# Altium schematic sheet Mako DSP QuadFlash and Boot.SchDoc("Mako DSP QuadFlash and Boot")
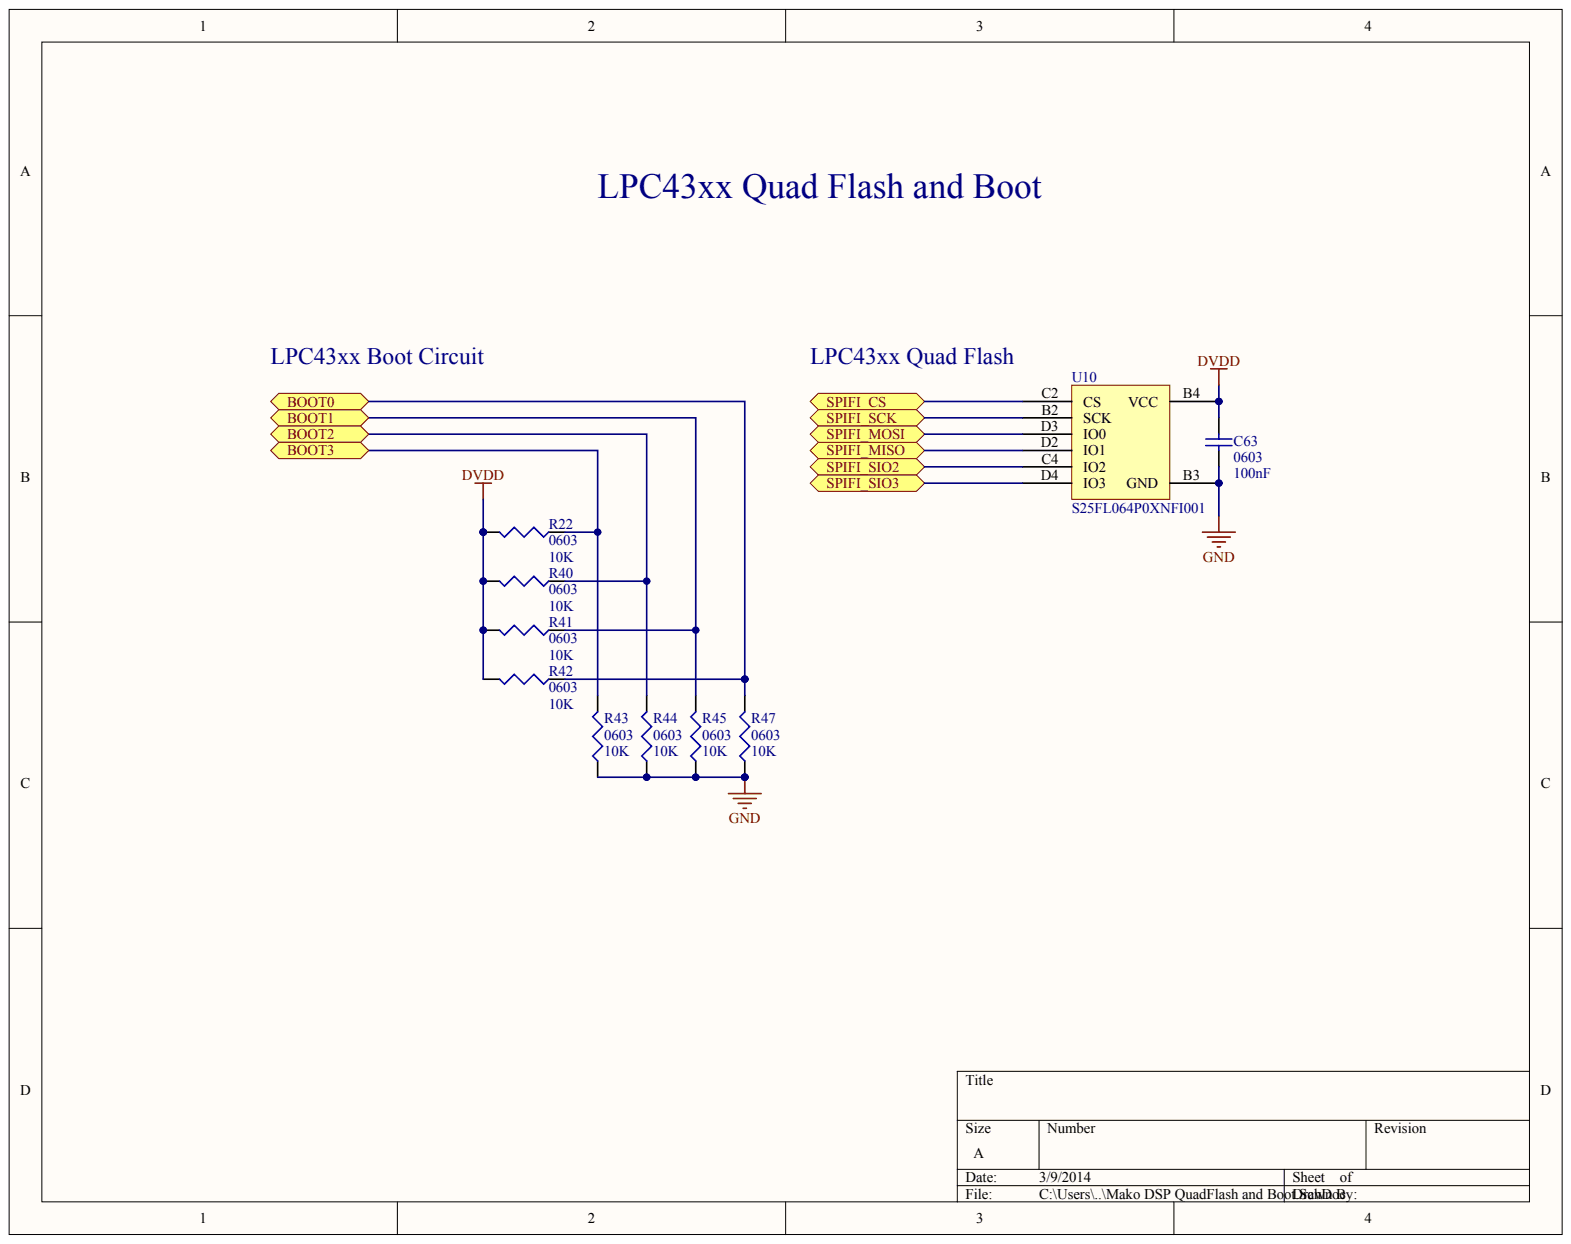
[diagram p0: Mako DSP QuadFlash and Boot.SchDoc]

## Parts
C63  0603  value 100nF
R22  0603  value 10K
R40  0603  value 10K
R41  0603  value 10K
R42  0603  value 10K
R43  0603  value 10K
R44  0603  value 10K
R45  0603  value 10K
R47  0603  value 10K
U10  S25FL064P0XNFI001

## Ports
BOOT0, BOOT1, BOOT2, BOOT3, SPIFI_CS, SPIFI_MISO, SPIFI_MOSI, SPIFI_SCK, SPIFI_SIO2, SPIFI_SIO3

## Nets
DVDD: C63.2 R22.2 R40.2 R41.2 R42.2 U10.B4(VCC)
GND: C63.1 R43.1 R44.1 R45.1 R47.1 U10.B3(GND)
BOOT0: R42.1 R47.2 ; port BOOT0
BOOT1: R41.1 R45.2 ; port BOOT1
BOOT2: R40.1 R44.2 ; port BOOT2
BOOT3: R22.1 R43.2 ; port BOOT3
SPIFI_CS: U10.C2(CS) ; port SPIFI_CS
SPIFI_MISO: U10.D2(IO1) ; port SPIFI_MISO
SPIFI_MOSI: U10.D3(IO0) ; port SPIFI_MOSI
SPIFI_SCK: U10.B2(SCK) ; port SPIFI_SCK
SPIFI_SIO2: U10.C4(IO2) ; port SPIFI_SIO2
SPIFI_SIO3: U10.D4(IO3) ; port SPIFI_SIO3

## Library data of the parts
R22 = (no symbol name): Value: 10K; Published: 8-Jun-2000; LatestRevisionDate: 29-May-2009; LatestRevisionNote: IPC-7351 Footprint Added.; PackageReference: J1-0603; PackageDescription: Chip Resistor; Publisher: Altium Limited
C63 R40-R45 R47 U10 = (no symbol name)
  C63: Published: 8-Jun-2000; LatestRevisionDate: 29-May-2009; LatestRevisionNote: IPC-7351 Footprint Added.; PackageReference: C1206; PackageDescription: Chip Capacitor
  R40-R45 R47: Published: 8-Jun-2000; LatestRevisionDate: 29-May-2009; LatestRevisionNote: IPC-7351 Footprint Added.; PackageReference: J1-0603; PackageDescription: Chip Resistor
  C63 R40-R45 R47: Publisher: Altium Limited
